# Altium schematic sheet Sch-QWA59_Reset_SW.SchDoc
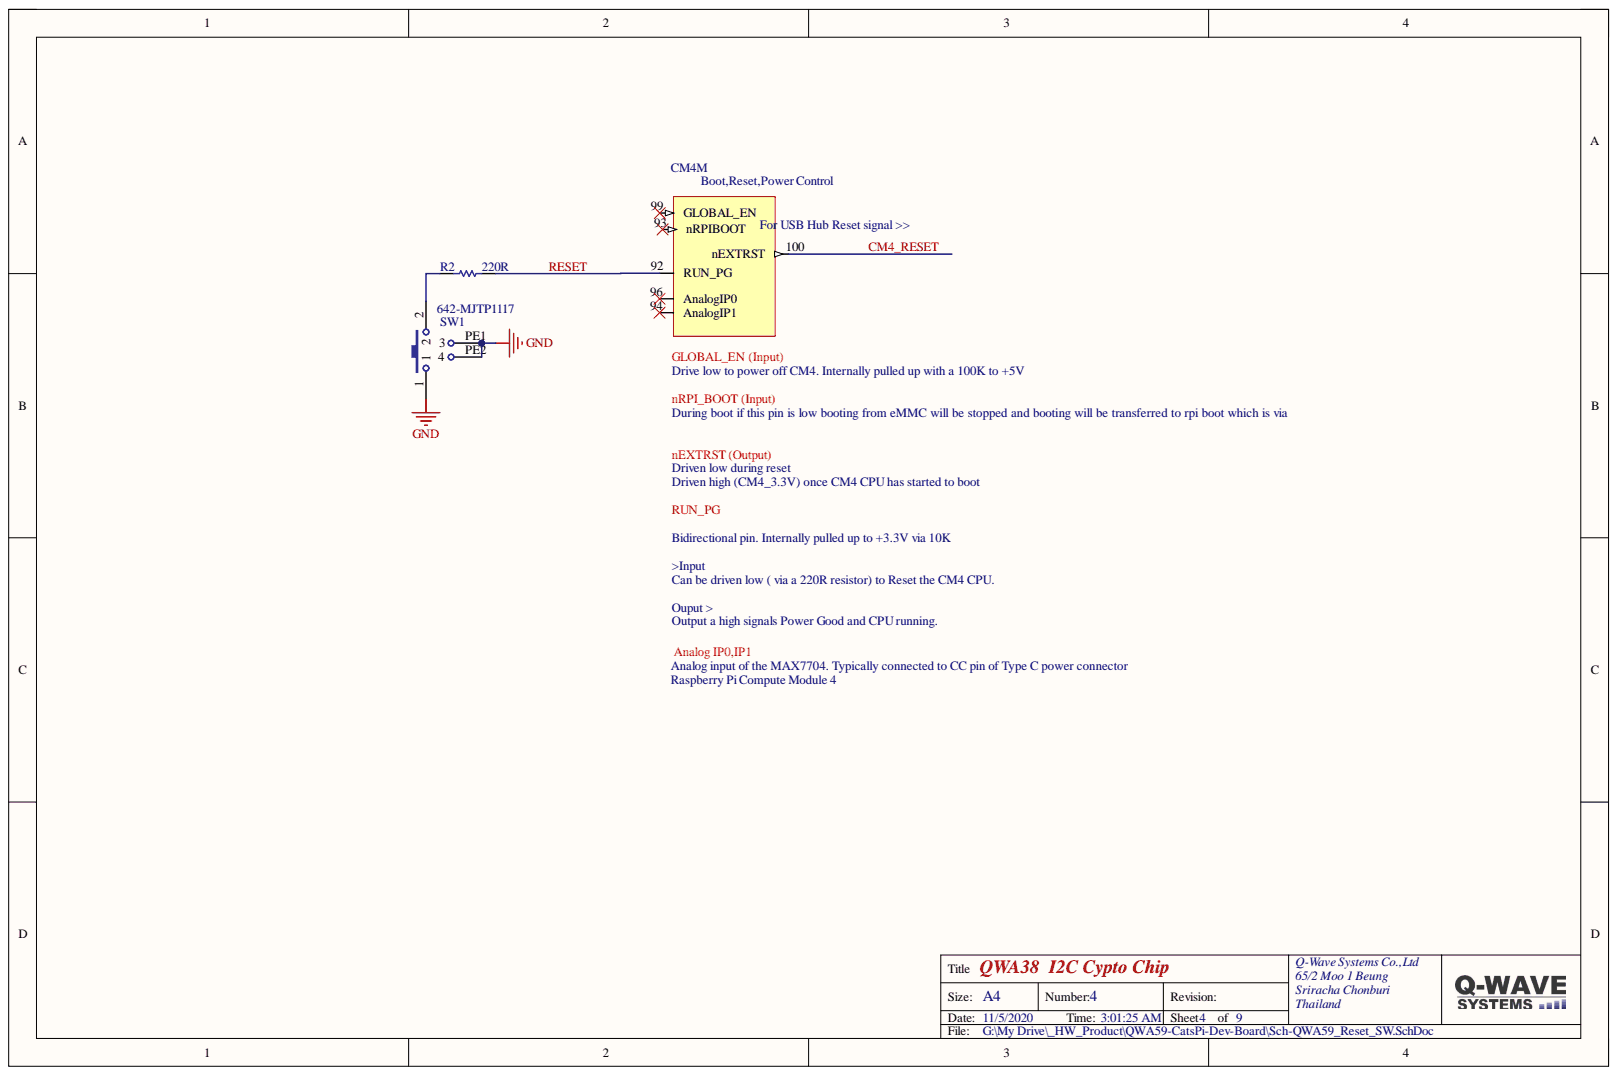
[diagram p0: Sch-QWA59_Reset_SW.SchDoc]

## Parts
CM4  Raspberry Pi Compute Module 4  [CM4-F40C-100DS-0.4v_1.5mm_0mm]  (part M)
R2  220R  [RES_0603]
SW1  MJTP1117  Supplier Part Number 1: 642-MJTP1117  [TSW DIP-3*6*4.3_V]

## Nets
GND: SW1.1 SW1.PE1 SW1.PE2
CM4_RESET: CM4.100
RESET: CM4.92(RUN_PG) R2.1
NetR2_2: R2.2 SW1.2

Not connected: CM4 93(nRPIBOOT) 94(AnalogIP1) 96(AnalogIP0) 99(GLOBAL_EN)

## Library data of the parts
CM4 = CM4: Raspberry Pi Compute Module 4 by Amornthep Phunsin; Component Kind: Standard; Library Name: CM4.SchLib
R2 = Res Semi: 470R Resistor 0603; Component Kind: Standard; Library Name: SCH_Passive.SchLib; Signal Integrity: Res Semi; Simulation: RES
SW1 = SW-PB: TACT SWITCH Size 6mmx4.3 MJTP1117; Component Kind: Standard; Supplier 1: Mouser; Library Name: QwaveLib.IntLib
